# Altium schematic sheet Sheet1.SchDoc
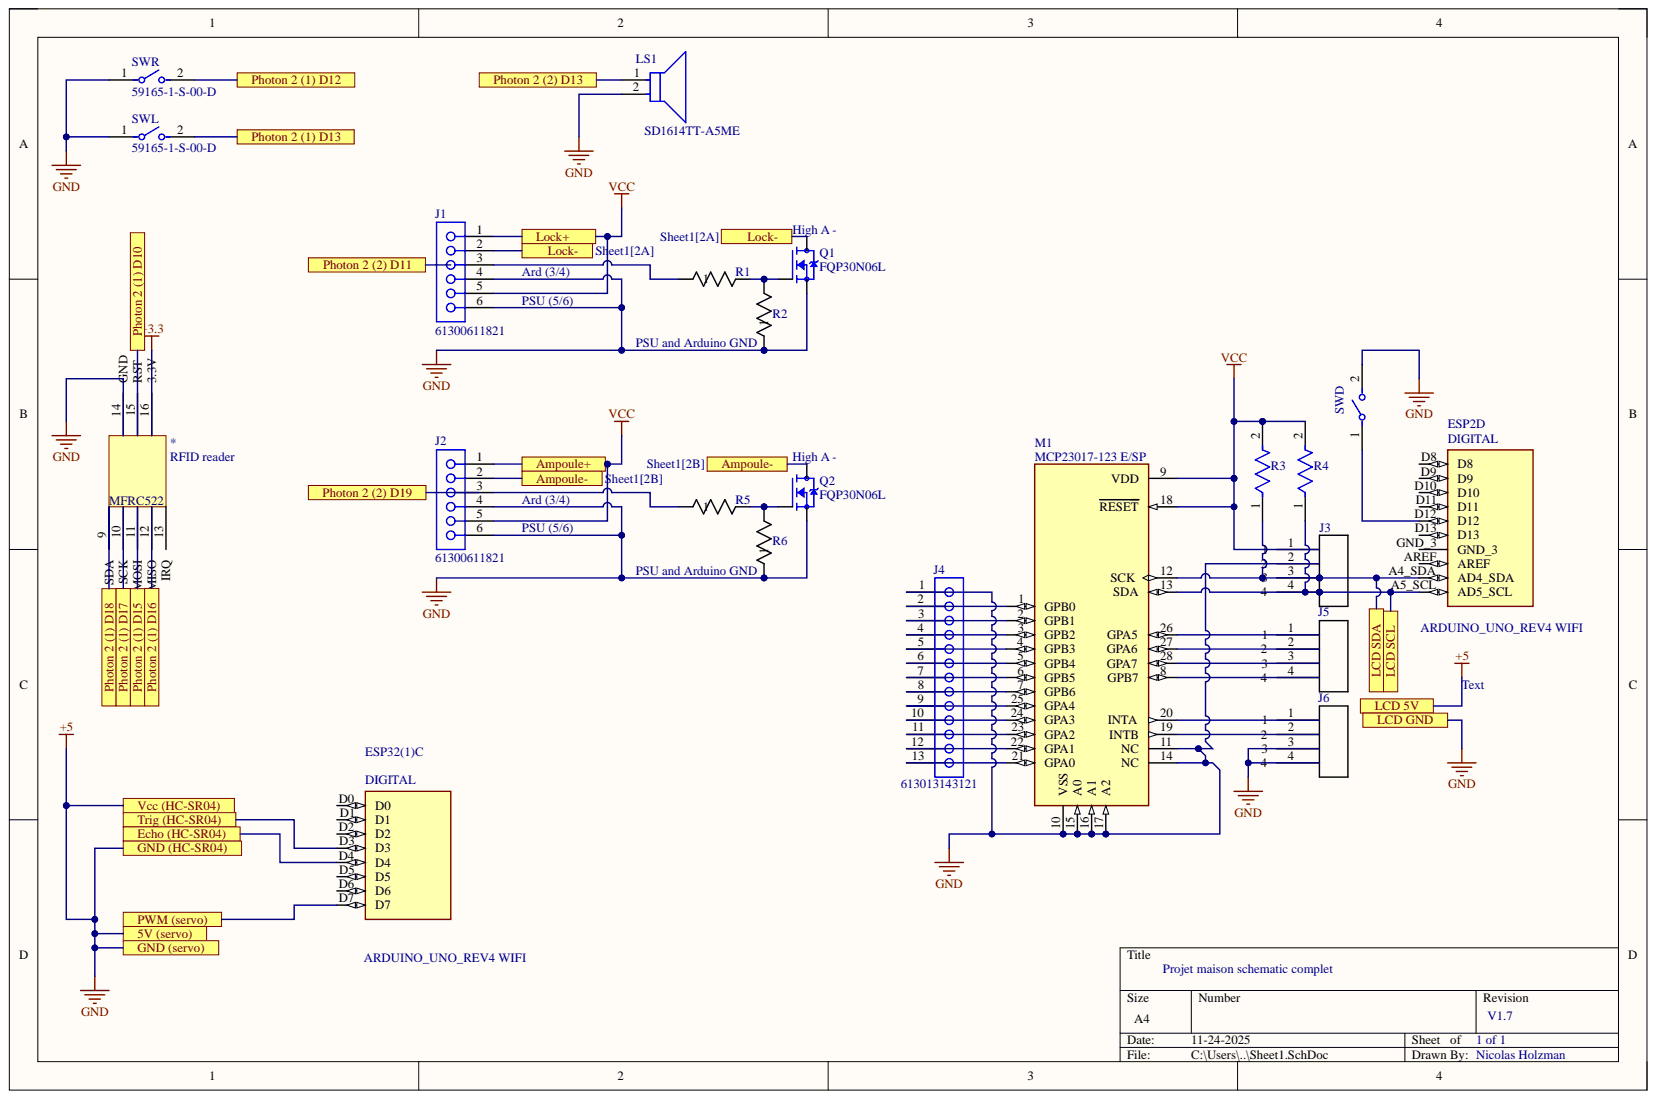
[diagram p0: Sheet1.SchDoc]

## Parts
*  RFID reader
ESP2  ARDUINO_UNO_REV4 WIFI  [ARDUINO_ARDUINO_UNO_REV3]  (part D)
ESP32(1)  ARDUINO_UNO_REV4 WIFI  [ARDUINO_ARDUINO_UNO_REV3]  (part C)
J1  61300611821  [61300611821]
J2  61300611821  [61300611821]
J3  non racist connector 4 pins  [non racist connector 4 pins-Footprint-1]  (value not drawn)
J4  613013143121  [613013143121]
J5  non racist connector 4 pins  [non racist connector 4 pins-Footprint-1]  (value not drawn)
J6  non racist connector 4 pins  [non racist connector 4 pins-Footprint-1]  (value not drawn)
LS1  SD1614TT-A5ME  [FP-SD1614TT-A5ME-MFG]
M1  MCP23017-123 E/SP  [New footprint 1]
Q1  FQP30N06L  [TO220V]
Q2  FQP30N06L  [TO220V]
R1  YR1B100RCC  [RES_TYCO_YR1_TYC]  (value not drawn)
R2  YR1B100RCC  [RES_TYCO_YR1_TYC]  (value not drawn)
R3  CRCW08054R70JNEA  [FP-CRCW0805-e3-IPC_B]  (value not drawn)
R4  CRCW08054R70JNEA  [FP-CRCW0805-e3-IPC_B]  (value not drawn)
R5  YR1B100RCC  [RES_TYCO_YR1_TYC]  (value not drawn)
R6  YR1B100RCC  [RES_TYCO_YR1_TYC]  (value not drawn)
SWD  59165-1-S-00-D  [FP-59165D-MFG]
SWL  59165-1-S-00-D  [FP-59165D-MFG]
SWR  59165-1-S-00-D  [FP-59165D-MFG]

## Ports
5V (servo), Ampoule+, Ampoule-, Echo (HC-SR04), GND (HC-SR04), GND (servo), LCD 5V, LCD GND, LCD SCL, LCD SDA, Lock+, Lock-, Photon 2 (1) D10, Photon 2 (1) D12, Photon 2 (1) D13, Photon 2 (1) D15, Photon 2 (1) D16, Photon 2 (1) D17, Photon 2 (1) D18, Photon 2 (2) D11, Photon 2 (2) D13, Photon 2 (2) D19, PWM (servo), Trig (HC-SR04), Vcc (HC-SR04)

## Nets
+3.3: *.16
VCC: J1.1 J1.5 J2.1 J2.5 J3.1 M1.9(VDD) M1.18(RESET) R3.2 R4.2
+5: *.14 J1.4 J1.6 J2.4 J2.6 J3.2 J4.1 J6.3 J6.4 LS1.2 M1.10(VSS) M1.11(NC) M1.14(NC) M1.15(A0) M1.16(A1) M1.17(A2) Q1.3 Q2.3 R2.1 R6.1 SWD.2 SWL.1 SWR.1 ; port 5V (servo), port GND (HC-SR04), port GND (servo), port LCD 5V, port LCD GND, port Vcc (HC-SR04)
Echo (HC-SR04): ESP32(1).D4 ; port Echo (HC-SR04)
LCD SCL: ESP2.A5_SCL(AD5_SCL) J3.4 M1.13(SDA) R4.1 ; port LCD SCL
LCD SDA: ESP2.A4_SDA(AD4_SDA) J3.3 M1.12(SCK) R3.1 ; port LCD SDA
Photon 2 (1) D10: *.15 ; port Photon 2 (1) D10
Photon 2 (1) D12: SWR.2 ; port Photon 2 (1) D12
Photon 2 (1) D13: SWL.2 ; port Photon 2 (1) D13
Photon 2 (1) D15: *.11 ; port Photon 2 (1) D15
Photon 2 (1) D16: *.12 ; port Photon 2 (1) D16
Photon 2 (1) D17: *.10 ; port Photon 2 (1) D17
Photon 2 (1) D18: *.9 ; port Photon 2 (1) D18
Photon 2 (2) D13: LS1.1 ; port Photon 2 (2) D13
PWM (servo): ESP32(1).D7 ; port PWM (servo)
Trig (HC-SR04): ESP32(1).D3 ; port Trig (HC-SR04)
NetESP2_D12: ESP2.D12 SWD.1
NetJ1_2: J1.2 Q1.2
NetJ1_3: J1.3 R1.1
NetJ2_2: J2.2 Q2.2
NetJ2_3: J2.3 R5.1
NetJ4_2: J4.2 M1.1(GPB0)
NetJ4_3: J4.3 M1.2(GPB1)
NetJ4_4: J4.4 M1.3(GPB2)
NetJ4_5: J4.5 M1.4(GPB3)
NetJ4_6: J4.6 M1.5(GPB4)
NetJ4_7: J4.7 M1.6(GPB5)
NetJ4_8: J4.8 M1.7(GPB6)
NetJ4_9: J4.9 M1.25(GPA4)
NetJ4_10: J4.10 M1.24(GPA3)
NetJ4_11: J4.11 M1.23(GPA2)
NetJ4_12: J4.12 M1.22(GPA1)
NetJ4_13: J4.13 M1.21(GPA0)
NetJ5_1: J5.1 M1.26(GPA5)
NetJ5_2: J5.2 M1.27(GPA6)
NetJ5_3: J5.3 M1.28(GPA7)
NetJ5_4: J5.4 M1.8(GPB7)
NetJ6_1: J6.1 M1.20(INTA)
NetJ6_2: J6.2 M1.19(INTB)
NetQ1_1: Q1.1 R1.2 R2.2
NetQ2_1: Q2.1 R5.2 R6.2
N00001: ; port Photon 2 (2) D19
N00002: ; port Photon 2 (2) D11

Not connected: * 13; ESP2 AREF D8 D9 D10 D11 D13 GND_3; ESP32(1) D0 D1 D2 D5 D6

## Library data of the parts
* = CMP-00026-1: Component Kind: Standard; Library Name: Nicolas Holzman
ESP2 ESP32(1) = CMP-033-000000-1: Microcontroller board based on the ATmega328P.; MP: ARDUINO UNO REV3; Check_prices: https://www.snapeda.com/parts/ARDUINO%20UNO%20REV3/Arduino/view-part/?ref=eda; Component Kind: Standard; Component Type: Standard; Design Item ID: ARDUINO_UNO_REV3; MF: Arduino; Package: Non-Standard Arduino; Pin Count: 32; SnapEDA_Link: https://www.snapeda.com/parts/ARDUINO%20UNO%20REV3/Arduino/view-part/?ref=snap; Source: ARDUINO_UNO_REV3.SchLib; Library Name: Nicolas Holzman
J1 J2 = CMP-1502-01322-3: NexarPartId: 21642411; Contact Resistance: 20mΩ; Current Rating: 3A; Gender: Female; Operating Supply Voltage: 250V; Component Kind: Standard; Lead Pitch: 2.54mm; Library Name: Altium Content Vault; Number of Pins: 6; Orientation: Vertical; RoHS: Compliant
J3 J5 J6 = CMP-00030-10: a; Component Kind: Standard; Library Name: Nicolas Holzman
J4 = CMP-1502-01426-3: NexarPartId: 61924733; Contact Resistance: 20mΩ; Current Rating: 3A; Gender: Female; Operating Supply Voltage: 250V; Component Kind: Standard; Lead Pitch: 2.54mm; Library Name: Altium Content Vault; Number of Pins: 13; RoHS: Non-Compliant
LS1 = CMP-08413-000006-1: BUZZER MAGNETIC 5V 80DB 2048HZ 1; Component Kind: Standard; Library Name: Altium Content Vault
M1 = CMP-00028-1: MICROCHIP - MCP23017-E/SP - Expander I/O, 16 bits, 1.7 MHz, I2C, S¨|rie, 1.8 V, 5.5 V, DIP; Clock Frequency: 1.7MHz; Max Supply Voltage: 5.5V; Min Supply Voltage: 1.8V; Nominal Supply Current: 1mA; Number of Bits: 16; Case/Package: DIP; Component Kind: Standard; Country of Assembly: Thailand; Country of Fabrication: United States of America; Country of Origin: Thailand; Export Control Classification Number (ECCN) Code: EAR99; Frequency: 1.7MHz; Harmonized Tariff Schedule (HTS) Code: 8542.39.0060; Interface: I2C; Introduction Date: 2005-06-24; Lead Free: Lead Free; Lifecycle Status: Production; Max Operating Temperature: 125°C; Min Operating Temperature: -40°C; Mounting Technology: Surface Mount, Through Hole; Number of I/Os: 16; Packaging: Bulk; Pins: 28; Projected EOL Date: 2034-06-24; REACH SVHC: No; RoHS: Compliant; Schedule B: 8542390000, 8542390000; Library Name: Nicolas Holzman
Q1 Q2 = CMP-035-000000-2: N-Channel 60 V 32A (Tc) 79W (Tc) Through Hole TO-220-3; MF: ON Semiconductor; Check_prices: https://www.snapeda.com/parts/FQP30N06L/Onsemi/view-part/?ref=eda; Component Kind: Standard; Component Type: Standard; Design Item ID: FQP30N06L; MP: FQP30N06L; Package: TO-220-3 ON; Pin Count: 3; SnapEDA_Link: https://www.snapeda.com/parts/FQP30N06L/Onsemi/view-part/?ref=snap; Source: FQP30N06L.SchLib; Library Name: Nicolas Holzman
R1 R2 R5 R6 = CMP-009-000005-1: No Description Available; Mfr_Name: TE Connectivity; Component Kind: Standard; Design Item ID: YR1B100RCC; Source: YR1B100RCC.SchLib; Library Name: Nicolas Holzman
R3 R4 = CMP-2001-03983-2: RES Thick Film, 4.7Ω, 5%, 0.125W, 200ppm/°C, 0805; Max Power Dissipation: 125mW; Resistance: 4.7R; Voltage Rating: 150V; Case Code (Imperial): 0805; Case Code (Metric): 2012; Case/Package: 0805; Component Kind: Standard; Composition: Thick Film; Contact Plating: Tin; Depth: 1.25mm; Features: Automotive AEC-Q200; Height: 0.45mm; Lead Free: Lead Free; Length: 2mm; Library Name: Altium Content Vault; Max Operating Temperature: 155°C; Military Standard: Not; Min Operating Temperature: -55°C; Number of Pins: 2; Number of Terminations: 2; Package Quantity: 5000; Packaging: Tape and Reel; Power Rating: 125mW; Radiation Hardening: No; Temperature Coefficient: 200ppm/°C; Termination: SMD/SMT; Tolerance: 5%; Voltage Rating (DC): 150V; Weight: 0.000194oz; Width: 0.049inch; Working Voltage: 150V
SWD SWL SWR = CMP-08625-000008-1: SWITCH REED SPST-NO 350MA 140V; Capacitance: 0.3pF; Operate Time: 1ms; Power Rating: 10W; Sensing Method: Magnetic; Throw Configuration: SPST; Component Kind: Standard; Length: 0.795inch; Library Name: Altium Content Vault; Max Operating Temperature: 105°C; Min Operating Temperature: -40°C; Mount: Surface Mount; Number of Pins: 2; Package Quantity: 1; Packaging: Tape and Reel; Radiation Hardening: No; Release Time: 1ms; RoHS Compliant: Yes; Series: 59165; Termination: Gull Wing; Width: 14mm
